# Altium schematic sheet Principal_Node.SchDoc
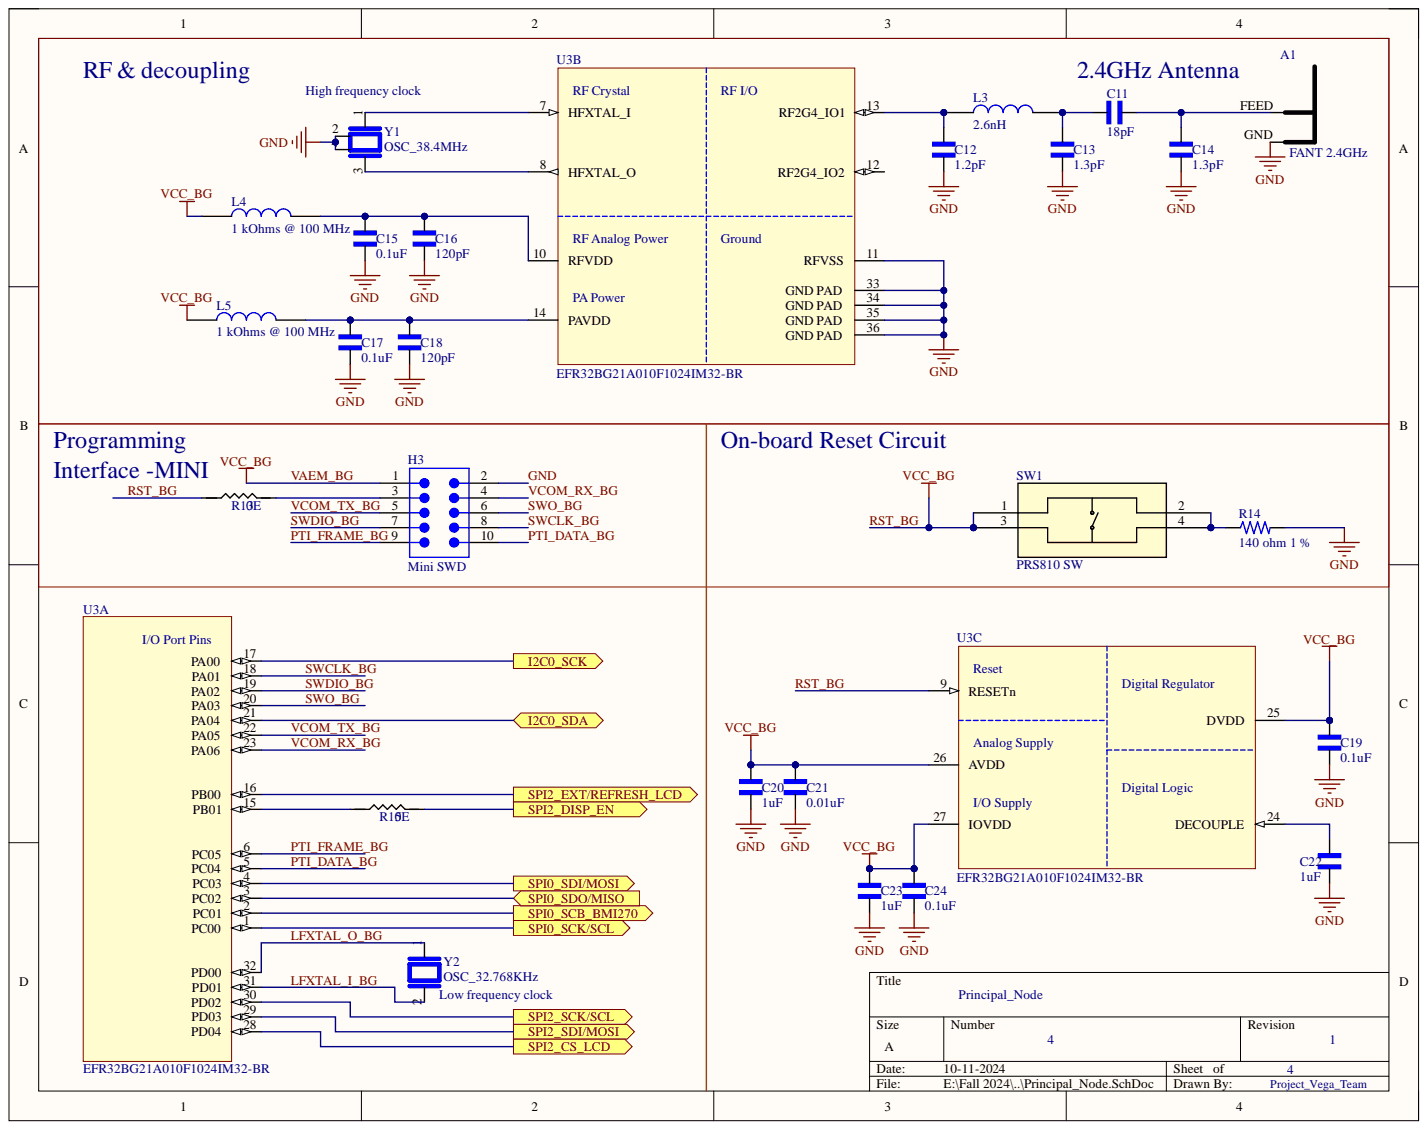
[diagram p0: Principal_Node.SchDoc]

## Parts
A1  FANT 2.4GHz  [FANT_2P4]
C11  18pF  [CAPC1608X90]
C12  1.2pF  [CAPC1608X90]
C13  1.3pF  [CAPC1608X90]
C14  1.3pF  [CAPC1608X90]
C15  0.1uF  [CAPC1608X90]
C16  120pF  [CAPC1608X90]
C17  0.1uF  [CAPC1608X90]
C18  120pF  [CAPC1608X90]
C19  0.1uF  [CAPC1608X90]
C20  1uF  [CAPC1608X90]
C21  0.01uF  [CAPC1608X90]
C22  1uF  [CAPC1608X90]
C23  1uF  [CAPC1608X90]
C24  0.1uF  [CAPC1608X90]
H3  Mini SWD  [FTSH-105-01-XXX-DV-K]
L3  2.6nH  [INDC1608X95N]
L4  1 kOhms @ 100 MHz  [INDC1608X95N]
L5  1 kOhms @ 100 MHz  [INDC1608X95N]
R13  value 0E  [1206_Passive_Resitor]  (hidden comment: 10k)
R14  140 ohm 1 %  [RES_0603_1608X06]
R15  value 0E  [1206_Passive_Resitor]  (hidden comment: 10k)
SW1  PRS810 SW  [PTS810_CNK]
U3  EFR32BG21A010F1024IM32-BR  [QFN32-EFR32xG2xIM32-BR]  (no BOM)  (parts A,B,C)
Y1  OSC_38.4MHz  [OSC_38.4MHz]
Y2  OSC_32.768KHz  [OSC_32.768KHz]

## Ports
I2C0_SCK, I2C0_SDA, SPI0_SCB_BMI270, SPI0_SCK/SCL, SPI0_SDI/MOSI, SPI0_SDO/MISO, SPI2_CS_LCD, SPI2_DISP_EN, SPI2_EXT/REFRESH_LCD, SPI2_SCK/SCL, SPI2_SDI/MOSI

## Nets
GND: A1.GND C12.2 C13.2 C14.2 C15.2 C16.2 C17.2 C18.2 C19.2 C20.2 C21.2 C22.2 C23.2 C24.2 H3.2 R14.2 U3.11(RFVSS) U3.33(GND_PAD) U3.34(GND_PAD) U3.35(GND_PAD) U3.36(GND_PAD) Y1.2 Y1.4
I2C0_SCK: U3.17(PA00) ; port I2C0_SCK
I2C0_SDA: U3.21(PA04) ; port I2C0_SDA
LFXTAL_I_BG: U3.31(PD01) Y2.2
LFXTAL_O_BG: U3.32(PD00) Y2.1
PTI_DATA_BG: H3.10 U3.5(PC04)
PTI_FRAME_BG: H3.9 U3.6(PC05)
RST_BG: C19.1 C20.1 C21.1 C23.1 C24.1 H3.1 L4.1 L5.1 R13.1 SW1.1 SW1.3 U3.9(RESETn) U3.25(DVDD) U3.26(AVDD) U3.27(IOVDD)
SPI0_SCB_BMI270: U3.2(PC01) ; port SPI0_SCB_BMI270
SPI0_SCK/SCL: U3.1(PC00) ; port SPI0_SCK/SCL
SPI0_SDI/MOSI: U3.4(PC03) ; port SPI0_SDI/MOSI
SPI0_SDO/MISO: U3.3(PC02) ; port SPI0_SDO/MISO
SPI2_CS_LCD: U3.28(PD04) ; port SPI2_CS_LCD
SPI2_DISP_EN: R15.2 ; port SPI2_DISP_EN
SPI2_EXT/REFRESH_LCD: U3.16(PB00) ; port SPI2_EXT/REFRESH_LCD
SPI2_SCK/SCL: U3.30(PD02) ; port SPI2_SCK/SCL
SPI2_SDI/MOSI: U3.29(PD03) ; port SPI2_SDI/MOSI
SWCLK_BG: H3.8 U3.18(PA01)
SWDIO_BG: H3.7 U3.19(PA02)
SWO_BG: H3.6 U3.20(PA03)
VCOM_RX_BG: H3.4 U3.23(PA06)
VCOM_TX_BG: H3.5 U3.22(PA05)
NetA1_FEED: A1.FEED C11.2 C14.1
NetC11_1: C11.1 C13.1 L3.2
NetC12_1: C12.1 L3.1 U3.13(RF2G4_IO1)
NetC15_1: C15.1 C16.1 L4.2 U3.10(RFVDD)
NetC17_1: C17.1 C18.1 L5.2 U3.14(PAVDD)
NetC22_1: C22.1 U3.24(DECOUPLE)
NetH3_3: H3.3 R13.2
NetR14_1: R14.1 SW1.2 SW1.4
NetR15_1: R15.1 U3.15(PB01)
NetU3_7: U3.7(HFXTAL_I) Y1.1
NetU3_8: U3.8(HFXTAL_O) Y1.3

Not connected: U3 12(RF2G4_IO2)

## Library data of the parts
A1 = FANT_2P4: Inverted F antenna; Component Kind: Standard; Library Name: Project_Vega.SchLib
C11-C24 = CC0603KRX7R9BB104: 0.1 ÂµF Â±10% 50V Ceramic Capacitor X7R 0603 (1608 Metric); MF: Yageo; Check_prices: https://www.snapeda.com/parts/CC0603KRX7R9BB104/Yageo/view-part/?ref=eda; Component Kind: Standard; MP: CC0603KRX7R9BB104; Package: 0603 Yageo; SnapEDA_Link: https://www.snapeda.com/parts/CC0603KRX7R9BB104/Yageo/view-part/?ref=snap; Library Name: Project_Vega.IntLib
H3 = Debug Connector Mini SWD - 0.05": Mini SWD 0.05" Pitch Connector - 10 Pin SMT Box Header; Manufacturer: Adafruit Industries; Manufacturer Part Number: 4048; Category: Connectors; Component Kind: Standard; ComponentLink1Description: https://media.digikey.com/pdf/Data%20Sheets/Adafruit%20PDFs/4048_Web.pdf?src-supplier=Digi-Key; ComponentLink1URL: https://media.digikey.com/pdf/Data%20Sheets/Adafruit%20PDFs/4048_Web.pdf?src-supplier=Digi-Key; Library Name: Connectors.IntLib; Pricing 1: 1=1.95000 (USD); RoHS: No; Stock 1: 201; Supplier 1: Digi-Key
L3-L5 = LQM18DN100M70L: Inductor with Inductance 10uH Tol.+/-20% Package 0603 (1608); MF: Murata Electronics; Availability: Unavailable; Component Kind: Standard; MP: LQM18DN100M70L; Package: 0603 Murata; Price: None; SnapEDA_Link: https://www.snapeda.com/parts/LQM18DN100M70L/Murata/view-part/4834755/?ref=snap; Library Name: Project_Vega.IntLib
R13 R15 = R_10KOhm_1206: 10 kOhms ±5% 0.25W, 1/4W Chip Resistor 1206 (3216 Metric) Automotive AEC-Q200 Thick Film; MFR Part #: RMCF1206FT10K0; Component Kind: Standard; Digikey Link: https://www.digikey.com/en/products/detail/stackpole-electronics-inc/RMCF1206FT10K0/1759669; LCSC Part#: C17902; Library Name: ECEN5730Lib_2023_05_DS.IntLib; Type: Basic
R14 = RC0603FR-07140RL: SMD Chip Resistor, 140 Ohm, ± 1%, 100 mW, 0603 [1608 Metric], Thick Film, General Purpose; Manufacturer: Yageo; Max Power Dissipation: 100mW; Temperature Coefficient: 100ppm/°C; Value: 140R; Voltage Rating: 50V; Case Code (Imperial): 0603; Case Code (Metric): 1608; Case/Package: 0603; Category: Chip SMD Resistors; Component Kind: Standard; ComponentLink1Description: https://datasheet.ciiva.com/11808/0900766b80c66297-11808828.pdf?src-supplier=Rs; ComponentLink1URL: https://datasheet.ciiva.com/11808/0900766b80c66297-11808828.pdf?src-supplier=Rs; ComponentLink2Description: https://datasheet.ciiva.com/15189/getdatasheetpartid-329205-15189606.pdf?src-supplier=Verical; ComponentLink2URL: https://datasheet.ciiva.com/15189/getdatasheetpartid-329205-15189606.pdf?src-supplier=Verical; Construction: Rectangular; Depth: 0.8mm; Features: Moisture Resistant; Height: 0.45mm; Lead Free: Lead Free; Length: 1.6mm; Manufacturer Part Number: RC0603FR-07140RL; Max Operating Temperature: 155°C; Military Standard: Not; Min Operating Temperature: -55°C; Mounting Technology: Surface Mount; Number of Terminations: 2; Packaging: Tape and Reel; Power Rating: 100mW; Pricing: 1=0.1, 10=0.019, 50=0.0112, 100=0.0093, 500=0.00622, 1000=0.00532, 5000=0.00382, 10000=0.00335, 25000=0.00287, 35000=0.00278, 50000=0.00257, 125000=0.00232 (USD); RoHS: Yes; RoHS Compliant: Yes; Series: RC; Stock: 238807; Supplier: Digikey; Supplier Part Number: 311-140HRCT-ND; Technology: THICK FILM; Termination: SMD/SMT; Tolerance: 1%; Voltage Rating (DC): 50V; Library Name: Project_Vega.IntLib
SW1 = PTS810SJM250SMTRLFS: Switch Tactile N.O. SPST Button J-Bend 0.05A 16V 150000Cycles 1.569N SMD T/R; Manufacturer: ITT C&K; Actuator Color: Grey; Actuator Type: Standard; Contact Current Rating: 50mA; Contact Resistance: 500mR; Illumination Type: Non-Illuminated; Number of Positions: 2; Operating Force: 160gf; Switch Function: Off-Mom; Switch Travel: 0.15mm; Case/Package: SMD/SMT; Color: Black; Component Kind: Standard; ComponentLink1Description: https://datasheet.ciiva.com/24082/pts810-4mar13-248115-24082262.pdf?src-supplier=Mouser; ComponentLink1URL: https://datasheet.ciiva.com/24082/pts810-4mar13-248115-24082262.pdf?src-supplier=Mouser; ComponentLink2Description: https://datasheet.ciiva.com/18514/pts810-4mar13-18514058.pdf?src-supplier=Digikey; ComponentLink2URL: https://datasheet.ciiva.com/18514/pts810-4mar13-18514058.pdf?src-supplier=Digikey; ComponentLink3Description: https://datasheet.ciiva.com/18514/pts810-4mar13-18514059.pdf?src-supplier=Digikey; ComponentLink3URL: https://datasheet.ciiva.com/18514/pts810-4mar13-18514059.pdf?src-supplier=Digikey; ComponentLink4Description: https://datasheet.ciiva.com/18514/pts810-4mar13-18514060.pdf?src-supplier=Digikey; ComponentLink4URL: https://datasheet.ciiva.com/18514/pts810-4mar13-18514060.pdf?src-supplier=Digikey; Contact Plating: Silver; Current Rating: 50mA; Depth: 4.6mm; Lead Free: Lead Free; Length: 3.2mm; Manufacturer Part Number: PTS810SJM250SMTRLFS; Max Current Rating: 50mA; Max Operating Temperature: 85°C; Mechanical Life: 150000Cycles; Min Operating Temperature: -40°C; Mounting Technology: Surface Mount; Orientation: Straight; Package Quantity: 2900; Packaging: Tape and Reel; Pins: 4; Pricing: 1=0.49, 10=0.474, 25=0.4568, 100=0.3954, 250=0.36028, 500=0.3339, 1000=0.29876, 2900=0.28294, 5800=0.26185, 14500=0.24428 (USD); RoHS: Yes; RoHS Compliant: Yes; Series: PTS810; Stock: 130228; Supplier: Digikey; Supplier Part Number: CKN10502CT-ND; Termination: J-Bend; Throw Configuration: SPST; Voltage Rating (DC): 16V; Library Name: Project_Vega.IntLib
U3 = EFR32BG21A010F1024IM32-BR: Blue Gecko, QFN32, 2.4G, 10dB, Bluetooth 5.1, 1024kB, 96kB(RAM), 20 GPIO; Manufacturer: Silicon Labs; Category: RF Receivers, Transceivers; ComponentLink1Description: https://www.silabs.com/documents/login/data-sheets/efr32bg21-datasheet.pdf?src-supplier=Digikey; ComponentLink1URL: https://www.silabs.com/documents/login/data-sheets/efr32bg21-datasheet.pdf?src-supplier=Digikey; ComponentLink2Description: https://datasheet.octopart.com/EFR32BG21A010F1024IM32-BR-Silicon-Labs-datasheet-142046433.pdf?src-supplier=Arrow.cn; ComponentLink2URL: https://datasheet.octopart.com/EFR32BG21A010F1024IM32-BR-Silicon-Labs-datasheet-142046433.pdf?src-supplier=Arrow.cn; Manufacturer Part Number: EFR32BG21A010F1024IM32-BR; Pricing: 1=4.27, 10=3.816, 25=3.4348, 100=3.1293, 250=2.82404, 500=2.6875 (USD); RoHS: No; Stock: 38892; Supplier: Digikey; Supplier Part Number: 336-5869-1-ND; Library Name: Project_Vega.SchLib
Y1 = OSC_38.4MHz: 38.4 MHz ±10ppm Crystal 10pF 40 Ohms 4-SMD, No Lead; MF: {'manufacturer': u'Murata Electronics'}; MP: XRCGB38M400F2P00R0; Availability: Unavailable; Component Kind: Standard; Package: None; Price: None; Supplier 1: Digikey; Supplier Part Number 1: 4606-TZ3398BCT-ND; Library Name: Project_Vega.IntLib
Y2 = OSC_32.768KHz: Tuning Fork Crystal 32.768kHz in 1.2 x 2.0mm package; MF: Micro Crystal; MP: CM8V-T1A-32.768KHZ-7PF-20PPM-TA-QC; Availability: Not in stock; Check_prices: https://www.snapeda.com/parts/CM8V-T1A-32.768KHZ-7PF-20PPM-TA-QC/Micro+Crystal/view-part/?ref=eda; Component Kind: Standard; Package: SMD-2 Micro Crystal; Price: None; SnapEDA_Link: https://www.snapeda.com/parts/CM8V-T1A-32.768KHZ-7PF-20PPM-TA-QC/Micro+Crystal/view-part/?ref=snap; Supplier 1: Digikey; Supplier Part Number 1: 2195-CM8V-T1A-32.768KHZ-7PF-20PPM-TA-QCCT-ND; Library Name: Project_Vega.IntLib
